# Altium schematic sheet Shematic.SchDoc(Shematic)
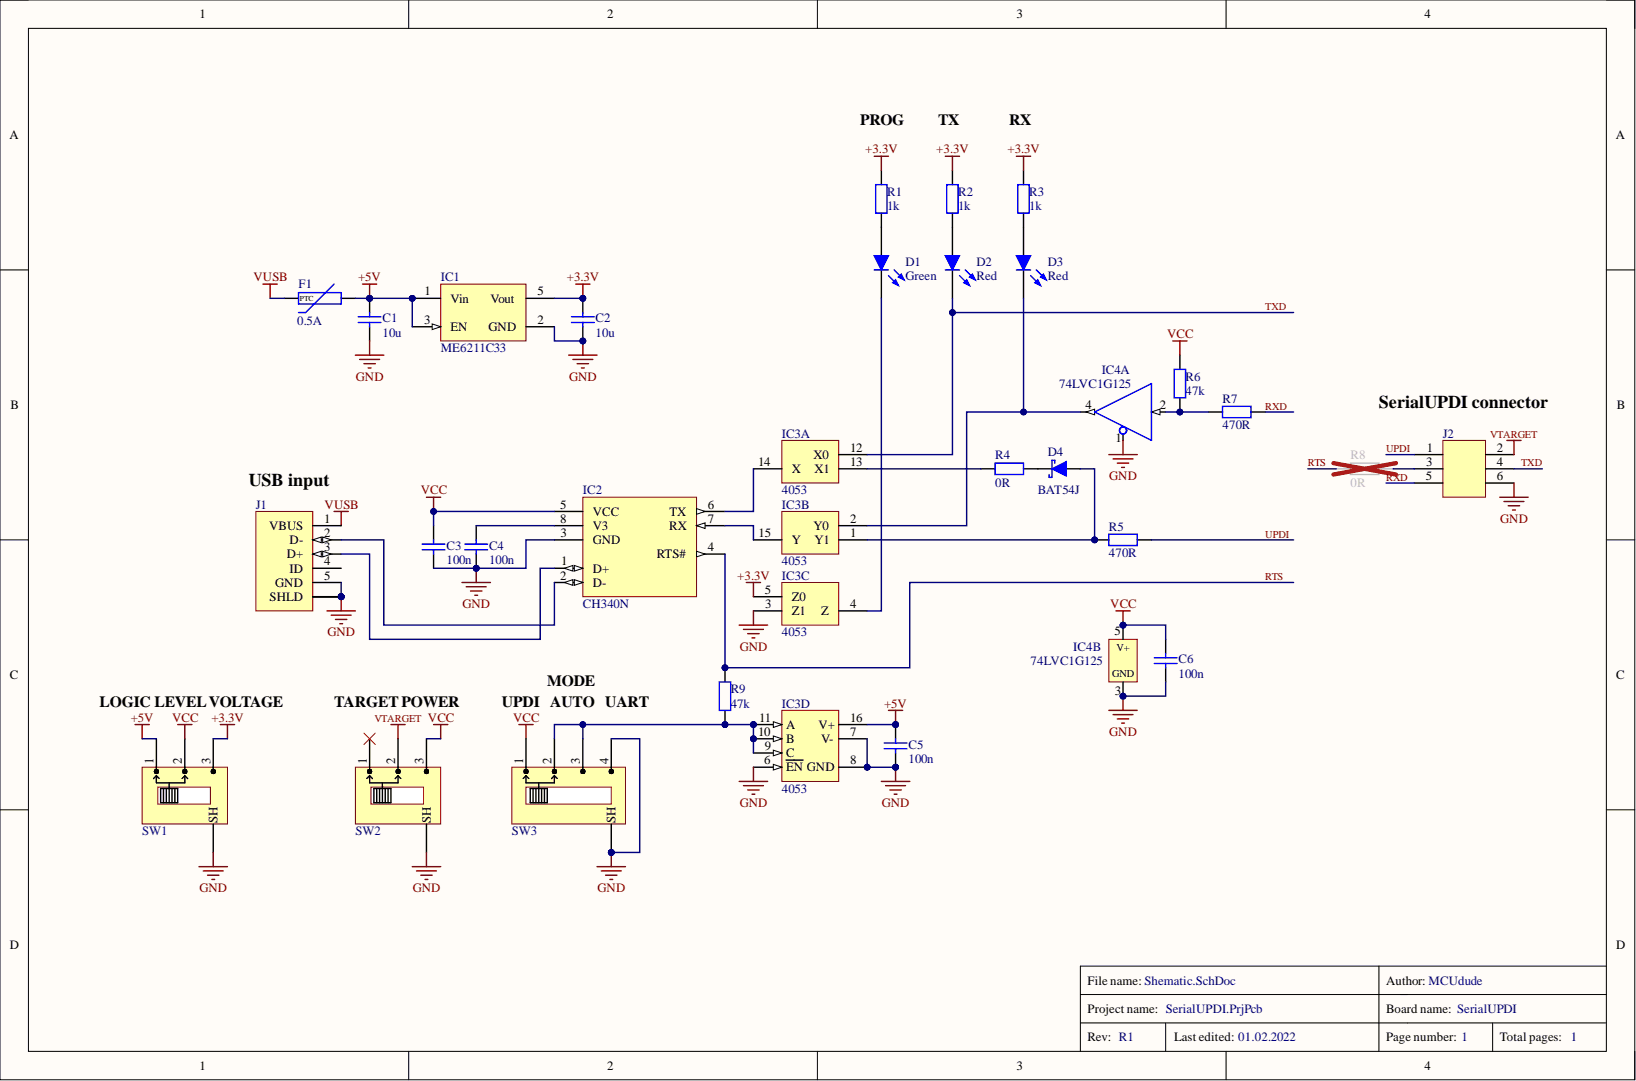
[diagram p0: Shematic.SchDoc]

## Parts
C1  value 10u  [0805 CAPACITOR]  (hidden comment: Generic bipolar capacitor)
C2  value 10u  [0805 CAPACITOR]  (hidden comment: Generic bipolar capacitor)
C3  value 100n  [0805 CAPACITOR]  (hidden comment: Generic bipolar capacitor)
C4  value 100n  [0805 CAPACITOR]  (hidden comment: Generic bipolar capacitor)
C5  value 100n  [0805 CAPACITOR]  (hidden comment: Generic bipolar capacitor)
C6  value 100n  [0805 CAPACITOR]  (hidden comment: Generic bipolar capacitor)
D1  Green  [LED-GREEN-0805]
D2  Red  [LED-RED-0805]
D3  Red  [LED-RED-0805]
D4  BAT54J  [SOD323]
F1  Current Rating: 0.5A  [FUSE-PTC-1812]  (hidden comment: 15V 0.5A)
IC1  ME6211C33  [SOT-23-5]
IC2  CH340N  [SOIC8-N]
IC3  4053  [SOIC16-N]  (parts A,B,C,D)
IC4  74LVC1G125  [SOT-353]  (parts A,B)
J1  MICRO USB  [USB-MICRO-TH]  (value not drawn)
J2  HEADER 2x3 MALE  [HEADER-MALE-STRAIGHT-2x3]  (value not drawn)
R1  value 1k  [0805 RESISTOR]  (hidden comment: Generic resistor)
R2  value 1k  [0805 RESISTOR]  (hidden comment: Generic resistor)
R3  value 1k  [0805 RESISTOR]  (hidden comment: Generic resistor)
R4  value 0R  [0805 RESISTOR]  (hidden comment: Generic resistor)
R5  value 470R  [0805 RESISTOR]  (hidden comment: Generic resistor)
R6  value 47k  [0805 RESISTOR]  (hidden comment: Generic resistor)
R7  value 470R  [0805 RESISTOR]  (hidden comment: Generic resistor)
R8  value 0R  [0805 RESISTOR]  (hidden comment: Generic resistor)
R9  value 47k  [0805 RESISTOR]  (hidden comment: Generic resistor)
SW1  SW-SLIDE-SPDT-SMD  [SWITCH-SLIDE-SPDT-SMD]  (value not drawn)
SW2  SW-SLIDE-SPDT-SMD  [SWITCH-SLIDE-SPDT-SMD]  (value not drawn)
SW3  SW-SLIDE-SP3T-SMD  [SWITCH-SLIDE-SP3T-SMD]  (value not drawn)

## Nets
+3.3V: C2.2 IC1.5 IC3.5 R1.2 R2.2 R3.2 SW1.3
+5V: C1.2 C5.2 F1.2 IC1.1 IC1.3 IC3.16 SW1.1
GND: C1.1 C2.1 C3.1 C4.1 C5.1 C6.1 IC1.2 IC2.3 IC3.3 IC3.6 IC3.7 IC3.8 IC4.1 IC4.3 J1.5 J1.6 J1.7 J1.8 J1.9 J2.6 SW1.SHLD SW2.SHLD SW3.4 SW3.SHLD
VCC: C3.2 C6.2 IC2.5 IC4.5 R6.2 SW1.2 SW2.3 SW3.1
VTARGET: J2.2 SW2.2
VUSB: F1.1 J1.1
RTS: IC2.4 R8.1 R9.2
RXD: J2.5 R7.1
TXD: D2.K IC3.12 J2.4
UPDI: J2.1 R5.1
NetC4_2: C4.2 IC2.8
NetD1_A: D1.A R1.1
NetD1_K: D1.K IC3.4
NetD2_A: D2.A R2.1
NetD3_A: D3.A R3.1
NetD3_K: D3.K IC3.2 IC4.4
NetD4_A: D4.A IC3.1 R5.2
NetD4_K: D4.K R4.2
NetIC2_1: IC2.1 J1.3
NetIC2_2: IC2.2 J1.2
NetIC2_6: IC2.6 IC3.14
NetIC2_7: IC2.7 IC3.15
NetIC3_9: IC3.9 IC3.10 IC3.11 R9.1 SW3.2 SW3.3
NetIC3_13: IC3.13 R4.1
NetIC4_2: IC4.2 R6.1 R7.2
NetJ2_3: J2.3 R8.2

Not connected: J1 4; SW2 1

## Library data of the parts
C1 C2 = CAP-CER-0805-10U-10V: Cap Ceramic 0805 10u 10V Y5V; Notes: Generic, choose one that meets spec; Component Kind: Standard; Component type: Capacitor; Dielectric: Y5V; Package: 0805; Voltage rating: 10V; Library Name: MCUdude_library.SchLib
C3-C6 = CAP-CER-0805-100N-50V: Cap Ceramic 0805 100n 50V X7R; Notes: Generic, choose one that meets spec; Component Kind: Standard; Component type: Capacitor; Dielectric: X7R; Package: 0805; Voltage rating: 50V; Library Name: MCUdude_library.SchLib
D1 = LED-0805-GREEN: LED 0805 Green; Component Kind: Standard; Component Type: LED; Package: 0805; Library Name: MCUdude_library.SchLib
D2 D3 = LED-0805-RED: LED 0805 Red; Component Kind: Standard; Component Type: LED; Package: 0805; Library Name: MCUdude_library.SchLib
D4 = D-BAT54J: Single Signal Schottky Diodes 30V 200mA; Voltage Rating: 200V; Component Kind: Standard; Component Type: Diode; Current Rating: 200mA; Manufacturer Part Number: BAT54J; Package: SOD323; Library Name: MCUdude_library.SchLib
F1 = FUSE-PTC-1812-0.5A: PTC Resettable Fuse 15V 0.5A Surface Mount 1812; Manufacturer: Littelfuse; Manufacturer Part Number: 1812L050PR; Topro Art. nr: 224683; Component Kind: Standard; Component Type: Fuse; Package: 1812; Voltage Rating: 15V; Library Name: MCUdude_library.SchLib
IC1 = REG-POS-3.3V-6211C33: Positive 3.3V regulator 500mA SOT-23-5; Manufacturer: Micro One; Component Kind: Standard; Component type: IC; Manufacturer Part Number: ME6211C33; Package: SOT-23-5; Library Name: MCUdude_library.SchLib
IC2 = IC-CH340N: USB to UART USB full speed SOIC-8; Manufacturer: WCH; Component Kind: Standard; Component Type: IC; Manufacturer Part Number: CH340N; Package: SOIC-8; Library Name: MCUdude_library.SchLib
IC3 = IC-MC14053: 3x 2:1 analog mux/demux; Manufacturer: ON semiconductor; Component Kind: Standard; Component Type: IC; Manufacturer Part Number: MC14053; Package: SOIC16; Library Name: MCUdude_library.SchLib
IC4 = IC-74LVC1G125: Singe Buffer with 3-State Output; Component Kind: Standard; Component Type: IC; Manufacturer Part Number: 74LVC1G125; Package: SOT-353; Library Name: MCUdude_library.SchLib
J1 = CON-USB-MICRO-ANGLED-TH: USB micro angled TH; Manufacturer: Hirose; Manufacturer Part Number: XZ62D-B-5P8(30); Notes: Surface mount micro USB connector with through-hole mounting; Component Kind: Standard; Component Type: Connector; Manufacturer 2: SHOU HAN; Manufacturer Part Number 2: MicroXNJ; Library Name: MCUdude_library.SchLib
J2 = CON-HEADER-MALE-STRAIGHT-2x3: Header male straight 2x3; Component Kind: Standard; Component Type: Connector; Library Name: MCUdude_library.SchLib
R1-R3 = RES-0805-1k: Resistor 0805 1k 1%; Notes: Generic, choose one that meets spec; Component Kind: Standard; Component Type: Resistor; Package: 0805; Tolerence: 1%; Library Name: MCUdude_library.SchLib
R4 R8 = RES-0805-0R: Resistor 0805 0R 1%; Notes: Generic, choose one that meets spec; Component Kind: Standard; Component Type: Resistor; Package: 0805; Library Name: MCUdude_library.SchLib
R5 R7 = RES-0805-470R: Resistor 0805 470R 1%; Notes: Generic, choose one that meets spec; Component Kind: Standard; Component Type: Resistor; Package: 0805; Tolerence: 1%; Library Name: MCUdude_library.SchLib
R6 R9 = RES-0805-47k: Resistor 0805 47k 1%; Notes: Generic, choose one that meets spec; Component Kind: Standard; Component Type: Resistor; Package: 0805; Tolerence: 1%; Library Name: MCUdude_library.SchLib
SW1 SW2 = SW-SLIDE-SPDT-SMD: Slider switch SMD SPDT; Manufacturer: ALPS; Manufacturer Part Number: SSSS811101; Component Kind: Standard; Component Type: Slide switch; Manufacturer 2: Korean Hroparts Elec; Manufacturer Part Number 2: K3-1296S-E1; Library Name: MCUdude_library.SchLib
SW3 = SW-SLIDE-SP3T-SMD: Slider switch SMD SP3T; Manufacturer: ALPS; Manufacturer Part Number: SSSS812201; Component Kind: Standard; Component Type: Slide switch; Manufacturer 2: Korean Hroparts Elec; Manufacturer Part Number 2: K3-1310S-E1; Library Name: MCUdude_library.SchLib
